# Altium schematic sheet Sheet2.SchDoc(Sheet2)
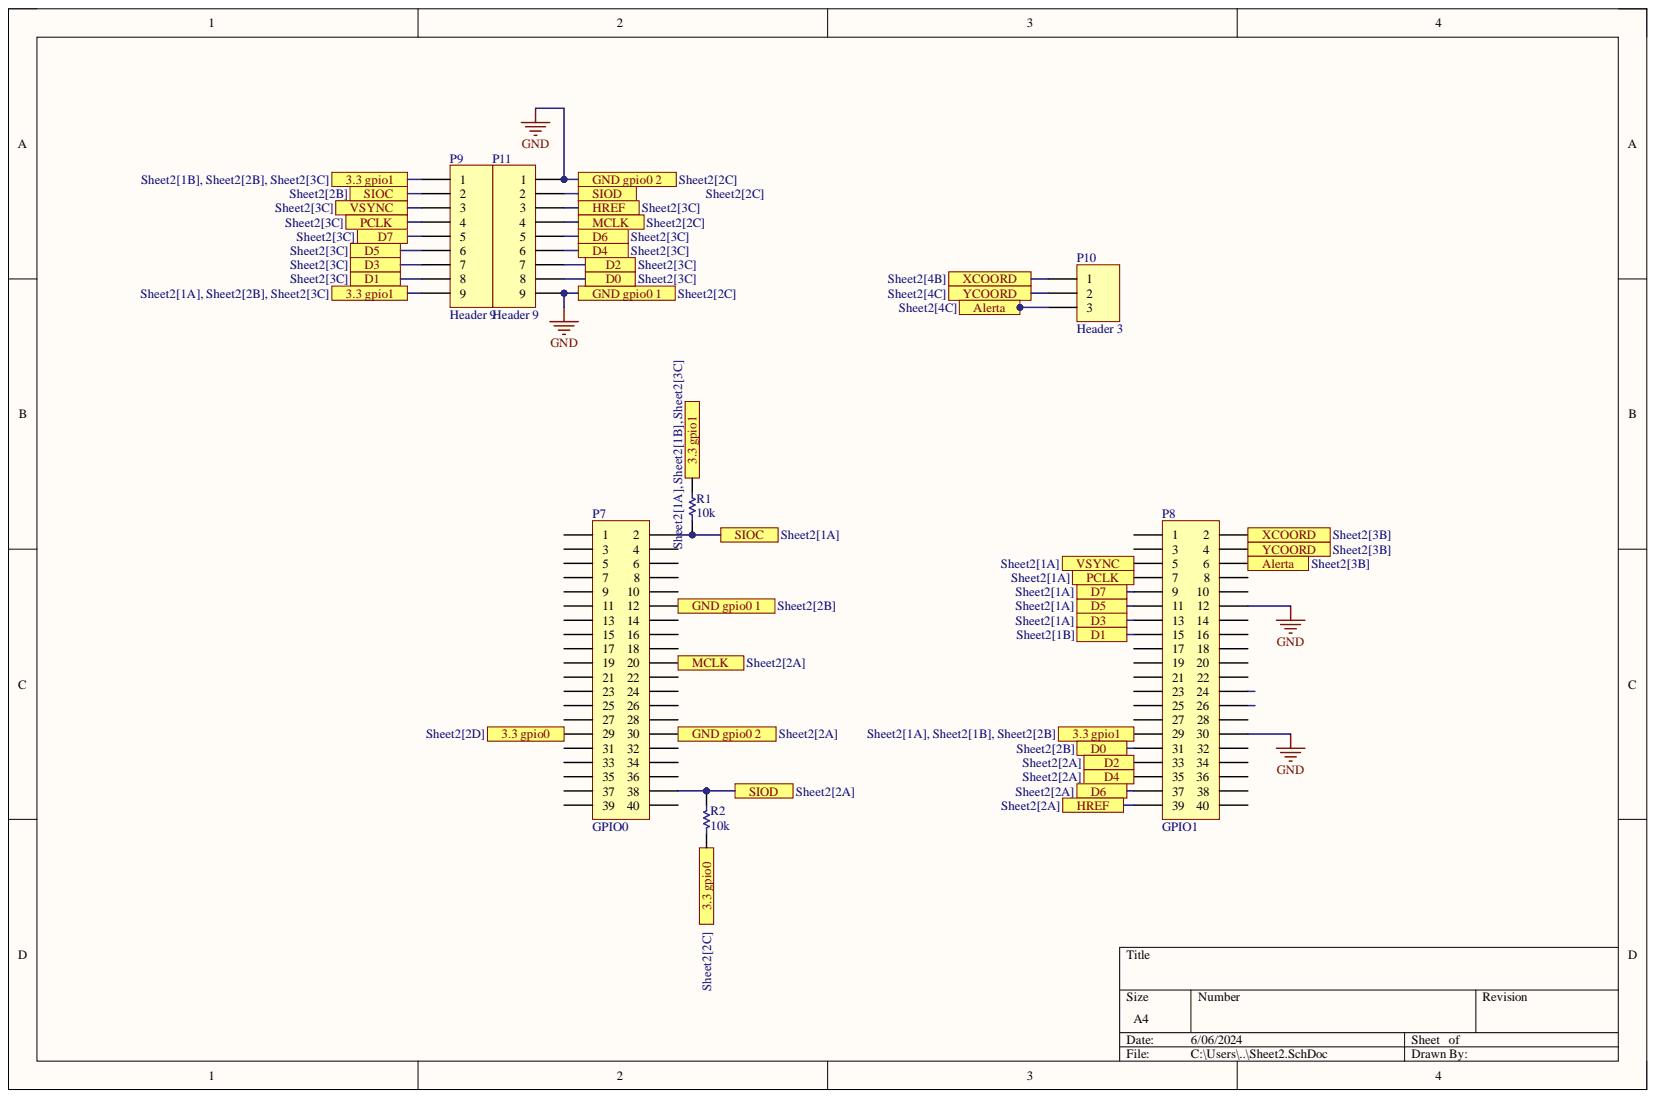
[diagram p0: Sheet2.SchDoc]

## Parts
P7  GPIO0  [HDR2X20]
P8  GPIO1  [HDR2X20]
P9  Header 9  [HDR1X9]
P10  Header 3  [HDR1X3]
P11  Header 9  [HDR1X9]
R1  value 10k  [RES 0.25 W - 0.4 In]  (hidden comment: Resistor)
R2  value 10k  [RES 0.25 W - 0.4 In]  (hidden comment: Resistor)

## Ports
3.3 gpio0, 3.3 gpio1, Alerta, D0, D1, D2, D3, D4, D5, D6, D7, GND gpio0 1, GND gpio0 2, HREF, MCLK, PCLK, SIOC, SIOD, VSYNC, XCOORD, YCOORD

## Nets
3.3 gpio0: P7.29 R2.2 ; port 3.3 gpio0
3.3 gpio1: P8.29 P9.1 P9.9 R1.2 ; port 3.3 gpio1
Alerta: P8.6 P10.3 ; port Alerta
D0: P8.31 P11.8 ; port D0
D1: P8.15 P9.8 ; port D1
D2: P8.33 P11.7 ; port D2
D3: P8.13 P9.7 ; port D3
D4: P8.35 P11.6 ; port D4
D5: P8.11 P9.6 ; port D5
D6: P8.37 P11.5 ; port D6
D7: P8.9 P9.5 ; port D7
GND: P7.12 P7.30 P8.12 P8.30 P11.1 P11.9 ; port GND gpio0 1, port GND gpio0 2
HREF: P8.39 P11.3 ; port HREF
MCLK: P7.20 P11.4 ; port MCLK
PCLK: P8.7 P9.4 ; port PCLK
SIOC: P7.2 P9.2 R1.1 ; port SIOC
SIOD: P7.38 P11.2 R2.1 ; port SIOD
VSYNC: P8.5 P9.3 ; port VSYNC
XCOORD: P8.2 P10.1 ; port XCOORD
YCOORD: P8.4 P10.2 ; port YCOORD

Not connected: P7 1 3 4 5 6 7 8 9 10 11 13 14 15 16 17 18 19 21 22 23 24 25 26 27 28 31 32 33 34 35 36 37 39 40; P8 1 3 8 10 14 16 17 18 19 20 21 22 23 24 25 26 27 28 32 34 36 38 40

## Library data of the parts
P7 P8 = Header 20X2: Header, 20-Pin, Dual row; Component Kind: Standard; LatestRevisionDate: 17-Jul-2002; LatestRevisionNote: Re-released for DXP Platform.; Library Name: Miscellaneous Connectors.IntLib; Signal Integrity: Connector; Publisher: Altium Limited
P9 P11 = Header 9: Header, 9-Pin; Component Kind: Standard; LatestRevisionDate: 17-Jul-2002; LatestRevisionNote: Re-released for DXP Platform.; Library Name: Miscellaneous Connectors.IntLib; Signal Integrity: Connector; Publisher: Altium Limited
P10 = Header 3: Header, 3-Pin; Component Kind: Standard; LatestRevisionDate: 17-Jul-2002; LatestRevisionNote: Re-released for DXP Platform.; Library Name: Miscellaneous Connectors.IntLib; Signal Integrity: Connector; Publisher: Altium Limited
R1 R2 = Resistor: Component Kind: Standard; Description: Resistor; Library Name: Integrated_Library_peke.IntLib
